# Altium schematic sheet Э3("All Documents",Physical)
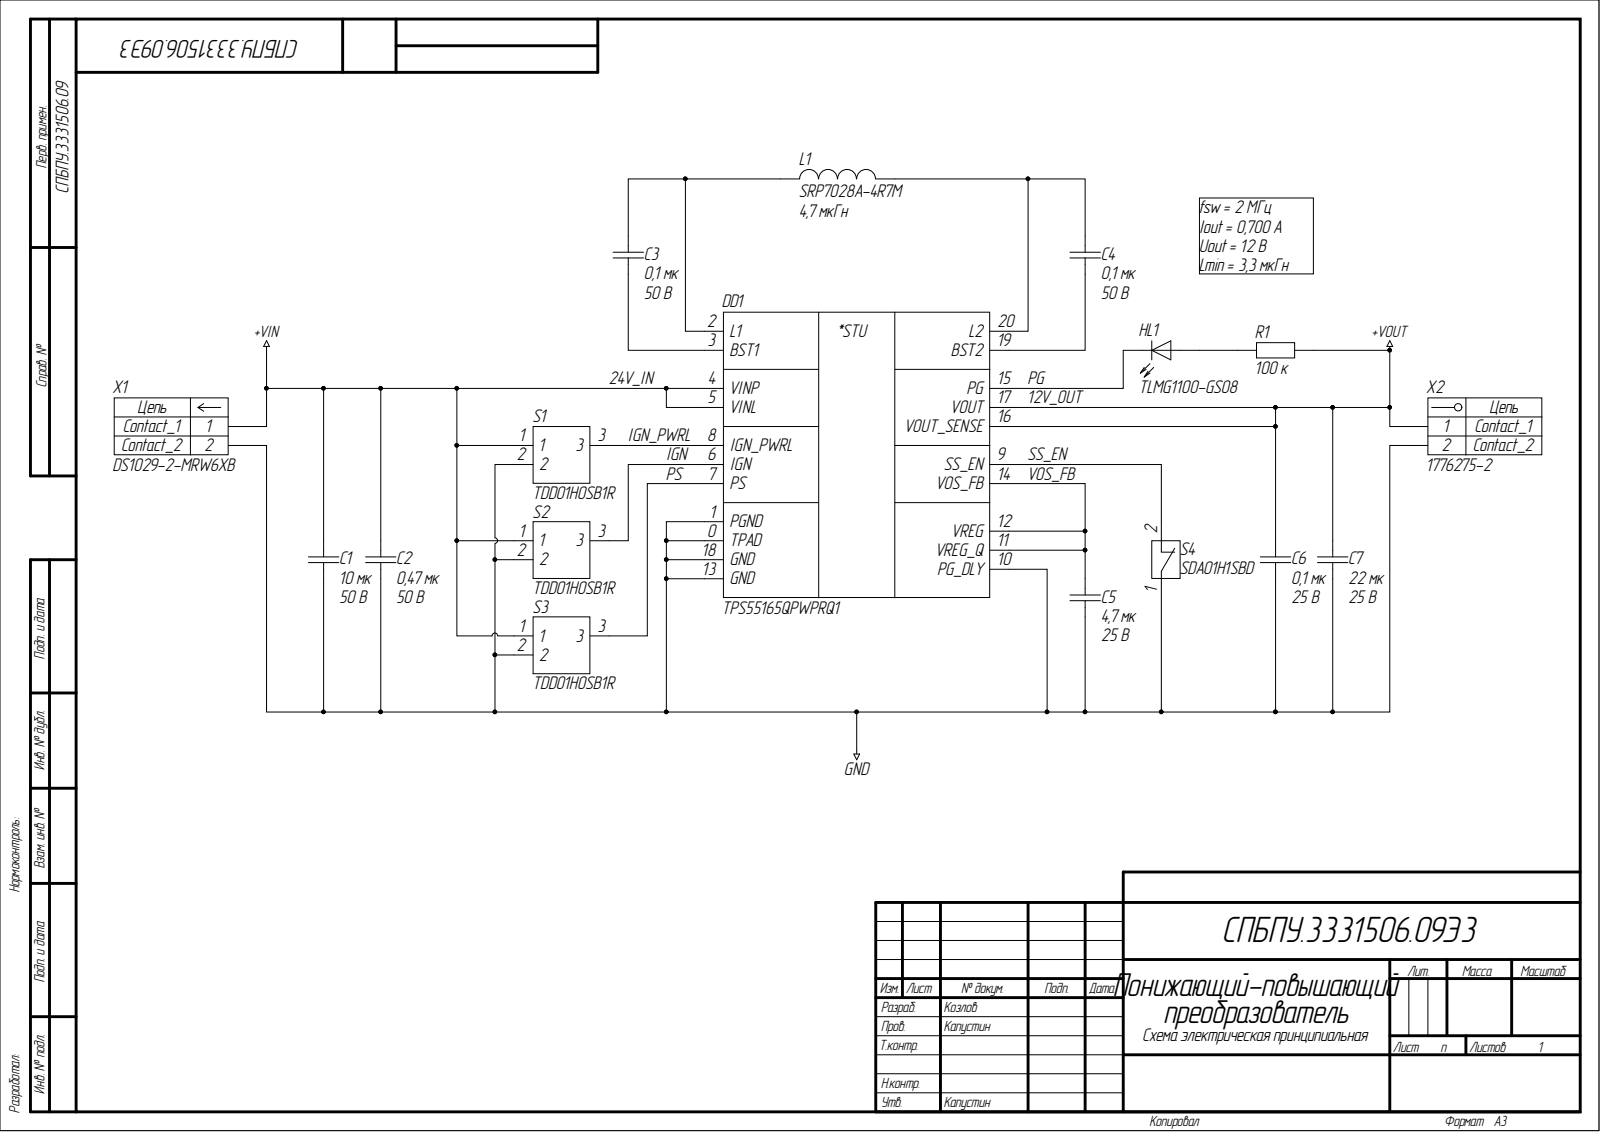
[diagram p0: Э3("All Documents",Physical)]

## Parts
C1  10 мк  [C0805]
C2  0,47 мк  Номинальное напряжение: 50 В  [C0603]
C3  0,1 мк  Номинальное напряжение: 50 В  [C0603]
C4  0,1 мк  Номинальное напряжение: 50 В  [C0603]
C5  4,7 мк  Номинальное напряжение: 25 В  [C0603]
C6  0,1 мк  [C0402]
C7  22 мк  Номинальное напряжение: 25 В  [C1206]
DD1  TPS55165QPWPRQ1  [HTSSOP-20]
HL1  TLMG1100-GS08  [HL0603]
L1  SRP7028A-4R7M  Номинал: 4,7 мкГн  [SRP-2-670X660X280]
R1  100 к  [R0603]
S1  TDD01H0SB1R  [JUMPER-SWITCH-02-600X240X220]
S2  TDD01H0SB1R  [JUMPER-SWITCH-02-600X240X220]
S3  TDD01H0SB1R  [JUMPER-SWITCH-02-600X240X220]
S4  SDA01H1SBD  [DIP-SWITCH-01-452X930X545]
X1  DS1029-2-MRW6XB  [DS1029-2-MRW6XB]
X2  1776275-2  [1776275-2]

## Nets
GND: C1.2 C2.2 C5.2 C6.2 C7.2 DD1.0(TPAD) DD1.1(PGND) DD1.10(PG_DLY) DD1.13(GND) DD1.18(GND) S1.2 S2.2 S3.2 S4.1 X1.2 X2.2
12V_OUT: C6.1 C7.1 DD1.16(VOUT_SENSE) DD1.17(VOUT) R1.2 X2.1
24V_IN: C1.1 C2.1 DD1.4(VINP) DD1.5(VINL) S1.1 S2.1 S3.1 X1.1
IGN: DD1.6(IGN) S2.3
IGN_PWRL: DD1.8(IGN_PWRL) S1.3
PG: DD1.15(PG) HL1.1
PS: DD1.7(PS) S3.3
SS_EN: DD1.9(SS_EN) S4.2
VOS_FB: C5.1 DD1.11(VREG_Q) DD1.12(VREG) DD1.14(VOS_FB)
NetC3_1: C3.1 DD1.2(L1) L1.1
NetC3_2: C3.2 DD1.3(BST1)
NetC4_1: C4.1 DD1.20(L2) L1.2
NetC4_2: C4.2 DD1.19(BST2)
NetHL1_2: HL1.2 R1.1

## Library data of the parts
C1 = c0091: =Описание; Фамилия: Паньков; Температурный коэффициент емкости: X5R; Рабочие температуры: от –55 °С до +85 °С; Производитель: YAGEO Corp.; Отклонение: ±10 %; Описание: Конденсатор чип 0805 10 мкФ 50 В ±10 %; Номинальное напряжение: 50 В; Наименование: CC0805KRX5R9BB106; Корпус: 0805; Database Table Name: Конденсаторы$; Номинал: 10 мк; Напряжение: 50 В; ID: c0091; HelpURL: https://www.yageo.com/upload/media/product/productsearch/datasheet/mlcc/UPY-GPHC_X5R_4V-to-50V_26.pdf; Description: Конденсатор чип 0805 10 мкФ 50 В ±10 %; Component Kind: Standard; Library Name: Database_Students.DbLib
C2 = c0052: =Описание; Фамилия: Паньков; Температурный коэффициент емкости: X5R; Рабочие температуры: от –55 °С до +85 °С; Производитель: YAGEO Corp.; Отклонение: ±10 %; Описание: Конденсатор чип 0603 470 нФ 50 В ±10 %; Наименование: CC0603KRX5R9BB474; Корпус: 0603; Database Table Name: Конденсаторы$; Номинал: 0,47 мк; Напряжение: 50 В; ID: c0052; HelpURL: https://www.yageo.com/upload/media/product/productsearch/datasheet/mlcc/UPY-GPHC_X5R_4V-to-50V_26.pdf; Description: Конденсатор чип 0603 470 нФ 50 В ±10 %; Component Kind: Standard; Library Name: Database_Students.DbLib
C3 C4 = c0034: =Описание; Фамилия: Ларионов; Температурный коэффициент емкости: X7R; Рабочие температуры: от –55 °С до +125 °С; Производитель: YAGEO Corp.; Отклонение: ±5 %; Описание: Конденсатор чип 0603 100 нФ 50 В ±5 %; Наименование: CC0603JRX7R9BB104; Корпус: 0603; Database Table Name: Конденсаторы$; Номинал: 0,1 мк; Напряжение: 50 В; ID: c0034; HelpURL: https://www.yageo.com/upload/media/product/products/datasheet/mlcc/UPY-GPHC_X7R_6.3V-to-50V_20.pdf; Description: Конденсатор чип 0603 100 нФ 50 В ±5 %; Component Kind: Standard; Library Name: Database_Students.DbLib
C5 = c0080: =Описание; Фамилия: Ларионов; Температурный коэффициент емкости: X5R; Рабочие температуры: от –55 °С до +85 °С; Производитель: YAGEO Corp.; Отклонение: ±10 %; Описание: Конденсатор чип 0603 4,7 мкФ 25 В ±10 %; Наименование: CC0603KRX5R8BB475; Корпус: 0603; Database Table Name: Конденсаторы$; Номинал: 4,7 мк; Напряжение: 25 В; ID: c0080; HelpURL: https://www.yageo.com/upload/media/product/productsearch/datasheet/mlcc/UPY-GPHC_X5R_4V-to-50V_26.pdf; Description: Конденсатор чип 0603 4,7 мкФ 25 В ±10 %; Component Kind: Standard; Library Name: Database_Students.DbLib
C6 = c0029: =Описание; Фамилия: Паньков; Температурный коэффициент емкости: X5R; Рабочие температуры: от –55 °С до +85 °С; Производитель: YAGEO Corp.; Отклонение: ±10 %; Описание: Конденсатор чип 0402 100 нФ 25 В ±10 %; Номинальное напряжение: 25 В; Наименование: CC0402KRX5R8BB104; Корпус: 0402; Database Table Name: Конденсаторы$; Номинал: 0,1 мк; Напряжение: 25 В; ID: c0029; HelpURL: https://www.yageo.com/upload/media/product/productsearch/datasheet/mlcc/UPY-GPHC_X5R_4V-to-50V_26.pdf; Description: Конденсатор чип 0402 100 нФ 25 В ±10 %; Component Kind: Standard; Library Name: Database_Students.DbLib
C7 = c0105: =Описание; Фамилия: Паньков; Температурный коэффициент емкости: X7R; Рабочие температуры: от –55 °С до +125 °С; Производитель: YAGEO Corp.; Отклонение: ±10 %; Описание: Конденсатор чип 1206 22 мкФ 25 В ±10 %; Наименование: CC1206KRX7R8BB226; Корпус: 1206; Database Table Name: Конденсаторы$; Номинал: 22 мк; Напряжение: 25 В; ID: c0105; HelpURL: https://www.yageo.com/upload/media/product/productsearch/datasheet/mlcc/UPY-GPHC_X7R_6.3V-to-50V_20.pdf; Description: Конденсатор чип 1206 22 мкФ 25 В ±10 %; Component Kind: Standard; Library Name: Database_Students.DbLib
DD1 = dd0009: =Описание; Частота переключения: 2 МГц; Фамилия: Козлов; Рабочие температуры: от -40 °С до +125 °С; Производитель: Texas Instruments; Описание: Понижающий-повышающий преобразователь 36В и 1 А; Коммутируемый ток: от 0 А до 1 А; Выходное напряжение: 5 В или 12 В; Входное напряжение: от 2 В до 36 В; Database Table Name: Преобразователи напряжения$; Наименование: TPS55165QPWPRQ1; Корпус: HTSSOP-20; ID: dd0009; HelpURL: https://www.ti.com/product/TPS55165-Q1; Description: Понижающий-повышающий преобразователь 36В и 1 А; Component Kind: Standard; Library Name: Database_Students.DbLib
HL1 = hl0005: =Описание; Цвет: Green; Фамилия: Ларионов; Рассеиваемая мощность: 90 мВт; Рабочие температуры: от –40 °С до +100 °С; Производитель: Vishay Intertechnology, Inc.; Описание: Светодиод чип 0603 зеленый; Номинальный прямой ток: 30 мА; Номинальное падение напряжения: 2,1 В; Номинальная сила света: 35 мкд; Номинальная длина волны: 570 нм; Корпус: 0603; Database Table Name: Светодиоды$; Наименование: TLMG1100-GS08; ID: hl0005; HelpURL: https://www.vishay.com/leds/list/product-83173/; Description: Светодиод чип 0603 зеленый; Component Kind: Standard; Library Name: Database_Students.DbLib
L1 = I0006: =Описание; Фамилия: Козлов; Ток насыщения: 10 А; Резонансная частота: 21 МГц; Рабочие температуры: от –55 °С до +150 °С; Рабочее напряжение: не указано; Производитель: Bourns, Inc.; Отклонение: ±20 %; Описание: Катушка индуктивности 4,7 мкГн ±20 %; Номинальный ток (при нагреве на 20 °С/40 °С): 5,5 А; Номинальное активное сопротивление: 37 мОм; Максимальное активное сопротивление: 40 мОм; Корпус: 6,7 x 6,6 x 2,8; Database Table Name: Катушки индуктивности$; Наименование: SRP7028A-4R7M; Индуктивность: 4,7 мкГн; ID: I0006; HelpURL: https://www.bourns.com/products/magnetic-products/power-inductors-aec-q200-compliant/product/SRP7028A; Description: Катушка индуктивности 4,7 мкГн ±20 %; Component Kind: Standard; Library Name: Database_Students.DbLib
R1 = r0094: =Описание; Фамилия: Водорезов; Рабочие температуры: от –55 °С до +155 °С; Производитель: YAGEO Corp.; Отклонение: ±1 %; Описание: Резистор чип 0603 100 кОм ±1 % 0,1 Вт; Номинальный ток: 1 А; Номинальное напряжение: 75 В; Наименование: RC0603FR-07100KL; Корпус: 0603; Database Table Name: Резисторы$; Номинал: 100 к; ID: r0094; HelpURL: https://www.yageo.com/upload/media/product/productsearch/datasheet/rchip/PYu-RC_Group_51_RoHS_L_11.pdf; Description: Резистор чип 0603 100 кОм ±1 % 0,1 Вт; Component Kind: Standard; Library Name: Database_Students.DbLib
S1-S3 = sw0005: =Описание; Фамилия: Козлов; Ток нагрузки: 25 мА @ 24 В; Сопротивление контакта: 100 мОм; Рабочие температуры: от –30 °С до +85 °С; Промывка: Разрешена; Производитель: C&K; Описание: 2-х позиционный движковый джампер-переключатель; Корпус: 6,00x2,40x2,20; Количество контактов: 3; Database Table Name: Переключатели$; Наименование: TDD01H0SB1R; ID: sw0005; HelpURL: https://www.ckswitches.com/products/switches/product-details/DIP/TDD/TDD01H0SB1R/; Description: 2-х позиционный движковый джампер-переключатель; Component Kind: Standard; Library Name: Database_Students.DbLib
S4 = sw0002: =Описание; Фамилия: Паньков; Ток нагрузки: 100 мА @ 5 В; Сопротивление контакта: 50 мОм; Рабочие температуры: от –40 °С до +85 °С; Промывка: Разрешена; Производитель: C&K; Описание: 2-х позиционный движковый переключатель; Корпус: 4,52х9,30х5,45; Количество контактов: 2; Database Table Name: Переключатели$; Наименование: SDA01H1SBD; ID: sw0002; HelpURL: https://www.ckswitches.com/products/switches/product-details/DIP/SDA/SDA01H1SBD/; Description: 2-х позиционный движковый переключатель; Component Kind: Standard; Library Name: Database_Students.DbLib
X1 = x0007: =Описание; Фамилия: Ларионов; Производитель: Connfly Electronic Co. LTD.; Описание: CWF 2 pin Type B 2,5 мм вилка угловой; Database Table Name: Разъемы$; Наименование: DS1029-2-MRW6XB; ID: x0007; HelpURL: http://www.connfly.com/productshow.aspx?id=352; Description: CWF 2 pin Type B 2,5 мм вилка угловой; Contact_2: Contact_2; Contact_1: Contact_1; Component Kind: Standard; Library Name: Database_Students.DbLib
X2 = x0005: =Описание; Фамилия: Паньков; Производитель: TE Connectivity; Описание: Клеммная колодка 2 pin 3,5 мм; Database Table Name: Разъемы$; Наименование: 1776275-2; ID: x0005; HelpURL: https://www.te.com/usa-en/product-1776275-2.html; Description: Клеммная колодка 2 pin 3,5 мм; Contact_2: Contact_2; Contact_1: Contact_1; Component Kind: Standard; Library Name: Database_Students.DbLib
